# Altium schematic sheet Top Level.SchDoc
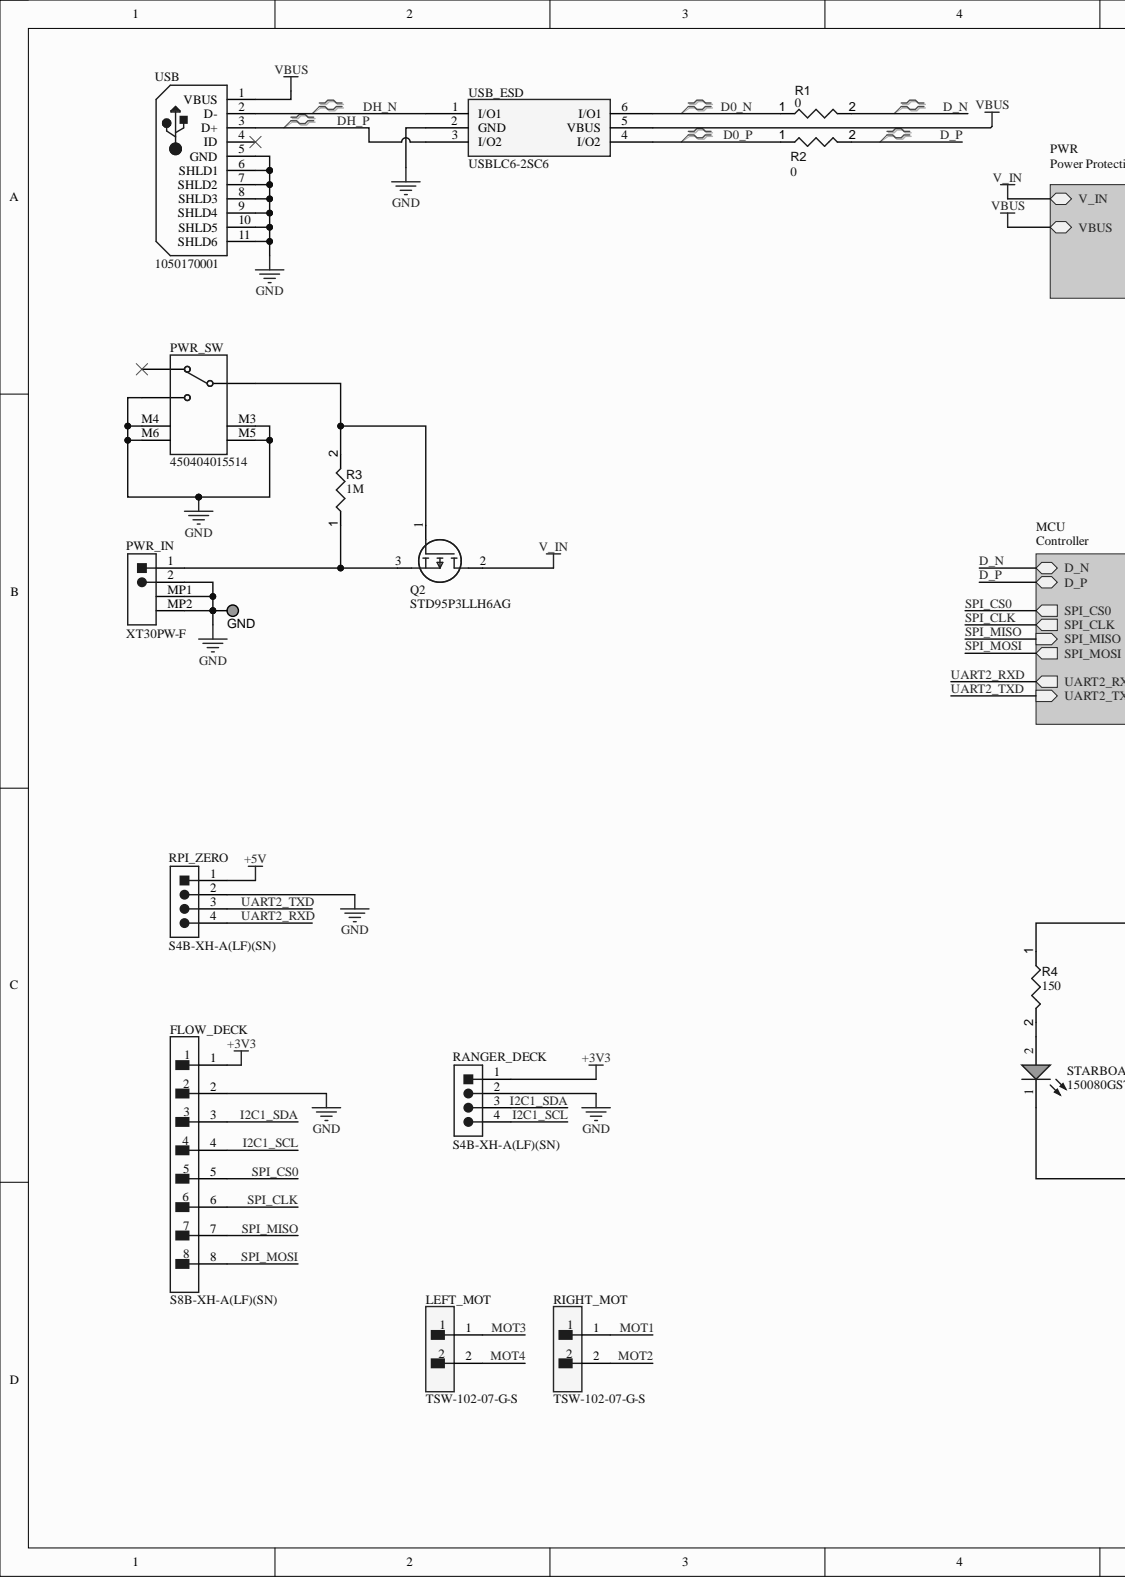
[diagram p0: Top Level.SchDoc, left]
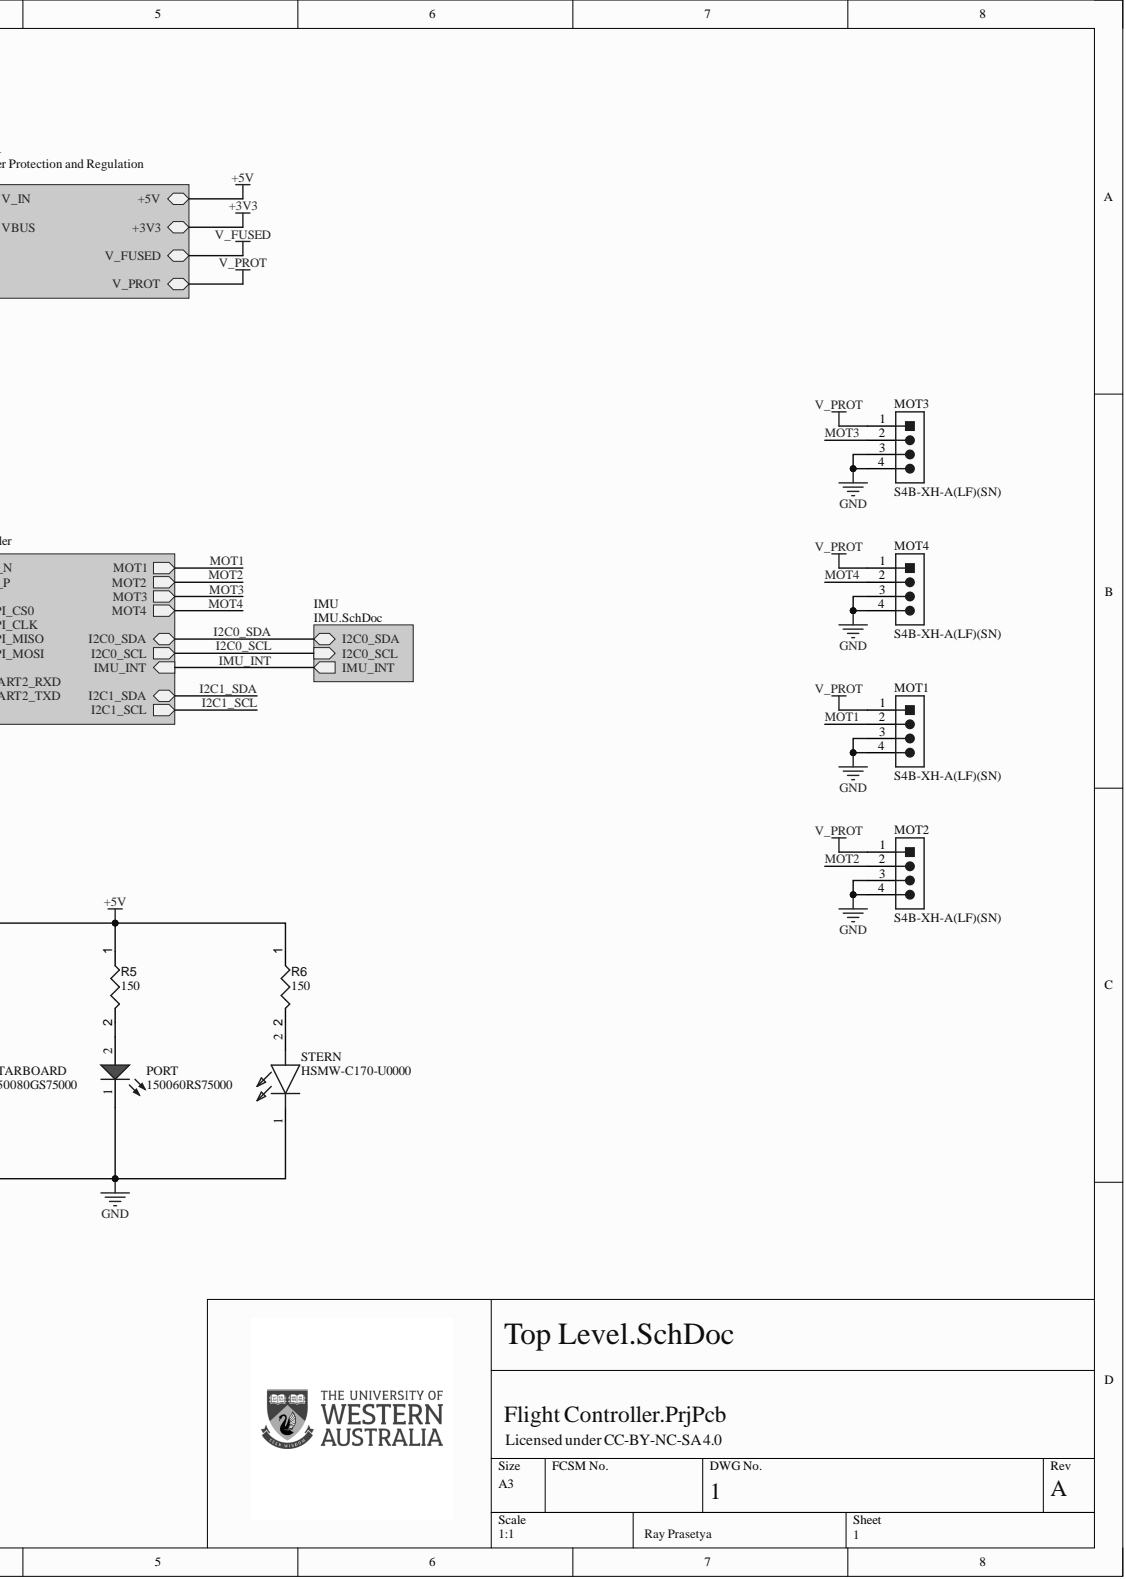
[diagram p1: Top Level.SchDoc, right]

## Parts
FLOW_DECK  S8B-XH-A(LF)(SN)  [FP-S8B-XH-A_LF_SN-MFG]
GND  5003  [KSTN-5003]  (value not drawn)
LEFT_MOT  TSW-102-07-G-S  [FP-TSW-102-07-G-S-MFG]
MOT1  S4B-XH-A(LF)(SN)  [JST-S4B-XH-A(LF)(SN)_V]
MOT2  S4B-XH-A(LF)(SN)  [JST-S4B-XH-A(LF)(SN)_V]
MOT3  S4B-XH-A(LF)(SN)  [JST-S4B-XH-A(LF)(SN)_V]
MOT4  S4B-XH-A(LF)(SN)  [JST-S4B-XH-A(LF)(SN)_V]
PORT  150060RS75000  [WL-SMCW_0603_150060xx75000]
PWR_IN  XT30PW-F  [XT30PWF]
PWR_SW  450404015514  [450404015514]
Q2  STD95P3LLH6AG  [DMP4047SK313]
R1  value 0  [FP-RC0603-MFG]  (hidden comment: RC0603FR-070RL)
R2  value 0  [FP-RC0603-MFG]  (hidden comment: RC0603FR-070RL)
R3  value 1M  [FP-AC0603-IPC_C]  (hidden comment: AC0603FR-071ML)
R4  value 150  [RESC1608X55X25NL10T15]  (hidden comment: RC0603FR-07150RL)
R5  value 150  [RESC1608X55X25NL10T15]  (hidden comment: RC0603FR-07150RL)
R6  value 150  [RESC1608X55X25NL10T15]  (hidden comment: RC0603FR-07150RL)
RANGER_DECK  S4B-XH-A(LF)(SN)  [JST-S4B-XH-A(LF)(SN)_V]
RIGHT_MOT  TSW-102-07-G-S  [FP-TSW-102-07-G-S-MFG]
RPI_ZERO  S4B-XH-A(LF)(SN)  [JST-S4B-XH-A(LF)(SN)_V]
STARBOARD  150080GS75000  [WL-SMCW_0805_150080xx75000]
STERN  HSMW-C170-U0000  [LEDC2012X90N]
USB  1050170001  Contact Style: USB  [USB-MICRO-B_V]
USB_ESD  USBLC6-2SC6  [STM-SOT23-6L_V]

## Nets
+3V3: FLOW_DECK.1 RANGER_DECK.1
+5V: R4.1 R5.1 R6.1 RPI_ZERO.1
GND: FLOW_DECK.2 GND.1 MOT1.3 MOT1.4 MOT2.3 MOT2.4 MOT3.3 MOT3.4 MOT4.3 MOT4.4 PORT.1 PWR_IN.2 PWR_IN.MP1 PWR_IN.MP2 PWR_SW.L2 PWR_SW.M3 PWR_SW.M4 PWR_SW.M5 PWR_SW.M6 RANGER_DECK.2 RPI_ZERO.2 STARBOARD.1 STERN.1 USB.5(GND) USB.6(SHLD1) USB.7(SHLD2) USB.8(SHLD3) USB.9(SHLD4) USB.10(SHLD5) USB.11(SHLD6) USB_ESD.2(GND)
V_IN: Q2.2
V_PROT: MOT1.1 MOT2.1 MOT3.1 MOT4.1
VBUS: USB.1(VBUS) USB_ESD.5(VBUS)
D0_N: R1.1 USB_ESD.6(I/O1)
D0_P: R2.1 USB_ESD.4(I/O2)
D_N: R1.2
D_P: R2.2
DH_N: USB.2(D-) USB_ESD.1(I/O1)
DH_P: USB.3(D+) USB_ESD.3(I/O2)
I2C1_SCL: FLOW_DECK.4 RANGER_DECK.4
I2C1_SDA: FLOW_DECK.3 RANGER_DECK.3
MOT1: MOT1.2 RIGHT_MOT.1
MOT2: MOT2.2 RIGHT_MOT.2
MOT3: LEFT_MOT.1 MOT3.2
MOT4: LEFT_MOT.2 MOT4.2
SPI_CLK: FLOW_DECK.6
SPI_CS0: FLOW_DECK.5
SPI_MISO: FLOW_DECK.7
SPI_MOSI: FLOW_DECK.8
UART2_RXD: RPI_ZERO.4
UART2_TXD: RPI_ZERO.3
NetPORT_2: PORT.2 R5.2
NetPWR_IN_1: PWR_IN.1 Q2.3 R3.1
NetPWR_SW_COM: PWR_SW.COM Q2.1 R3.2
NetPWR_SW_L1: PWR_SW.L1
NetR4_2: R4.2 STARBOARD.2
NetR6_2: R6.2 STERN.2
NetUSB_4: USB.4(ID)
I2C0_SCL:
I2C0_SDA:
IMU_INT:
V_FUSED:

## Library data of the parts
FLOW_DECK = CMP-002-00074-1: Conn Shrouded Header (4 Sides) HDR 8 POS 2.5mm Solder RA Thru-Hole Box; Component Kind: Standard; Library Name: Ray Prasetya
GND = CMP-002-00037-2: Test Point, Orange, Height 4.6 mm, Tail Length 3 mm, 1-Pin THD, RoHS; Manufacturer: Keystone; Case/Package: 5003; Colour: Orange; Component Kind: Standard; Connector Type: TestPoint; Contact Material: Bronze; Contact Plating: Tin; Datasheet URL: http://keyelco.com/userAssets/file/M65p56.pdf; Datasheet Version: Jun-15; Diameter: 2.54mm; Manufacturer URL: http://www.keyelco.com/; Mounting Technology: TH; Package Description: 1-Pin Through Hole Device, Dia 2.54 mm; Package Quantity: 10; Package Reference: 5003; Packaging: Bulk; Pins: 1; RoHS Compliant: True; Library Name: Ray Prasetya
LEFT_MOT RIGHT_MOT = CMP-02766-000084-1: CONN HEADER VERT 2POS 2.54MM; Manufacturer: Samtec; Conn Gender: Male; Conn Orientation: Straight; Current Rating: 6.3A; Number Of Contacts: 2; Voltage Rating: 550V; Automotive: No; Category: Conn; Component Kind: Standard; ComponentLink2Description: Datasheet; ComponentLink2URL: http://suddendocs.samtec.com/catalog_english/tsw_th.pdf; Contact Material: Gold,Nickel; Device Class L1: Connectors; Device Class L2: Headers and Wire Housings; Device Class L3: unset; Digikey Description: CONN HEADER VERT 2POS 2.54MM; Footprint Url: http://suddendocs.samtec.com/prints/tsw-xxx-xx-x-x-xx-xxx-footprint.pdf; Height: 8.71mm; Lead Free: Yes; Library Name: Altium Content Vault; Manufacturer Part Number: TSW-102-07-G-S; Mouser Description: Conn Unshrouded Header HDR 2 POS 2.54mm Solder ST Thru-Hole Automotive Bulk; Number Of Rows: 1; Octopart: https://octopart.com/tsw-102-07-g-s-samtec-272197; Package: TSW-102-07-G-S; Pitch: 2.54mm; RoHS: TRUE; Temperature: -55°C to +125°C; Temperature Range High: +125°C; Temperature Range Low: -55°C
MOT1-MOT4 RANGER_DECK RPI_ZERO = CMP-002-00034-2: Male Header, Pitch 2.5 mm, 1 x 4 Position, Height 6.1 mm, Tail Length 3.4 mm, -25 to 85 degC, RoHS, Bulk; Manufacturer: JST; Case/Package: S4B-XH-A(LF)(SN); Component Kind: Standard; Connector Type: Header; Datasheet URL: http://www.jst-mfg.com/product/pdf/eng/eXH.pdf; Datasheet Version: May-16; Gender: Male; Manufacturer URL: http://www.jst.com/home2.html; Max Operating Temperature: 85°C; Min Operating Temperature: -25°C; Mounting Technology: TH; Package Description: XH Series Disconnectable Crimp Style Connector, Pitch 2.5 mm, 1 x 4 Position, Height 6.1 mm, Tail Length 3.4 mm; Package Reference: S4B-XH-A(LF)(SN); RoHS Compliant: Unknown; Library Name: Ray Prasetya
PORT = CMP-007-00044-1: Single Color LED, Red, Water Clear, 1.1mm; Channels: 1; Forward Current: 30mA; Luminous Intensity-Nom: 250mcd; Overall Height: 0.7mm; Shape: Rectangular; Viewing Angle: 140°; Color: Red; Component Kind: Standard; Lens Style: WATER CLEAR; Max Operating Temperature: 85°C; Min Operating Temperature: -40°C; Mounting Technology: Surface Mount; Optoelectronic Device Type: SINGLE COLOR LED; Packaging: Tape and Reel; Pins: 2; Reach SVHC Compliant: Yes; RoHS Compliant: Yes; Size: 1.1mm; Terminal Pitch: 1.6mm; Library Name: Ray Prasetya
PWR_IN = CMP-002-00080-2: Socket; DC supply; XT30; female; PIN:2; on PCBs; THT; Colour: yellow; Manufacturer_Name: Amass; Component Kind: Standard; Datasheet Link: https://www.tme.eu/Document/ce4077e36b79046da520ca73227e15de/XT30PW%20SPEC.pdf; Design Item ID: XT30PW-F; Height: 5mm; Manufacturer_Part_Number: XT30PW-F; Source: SamacSys.SchLib; Library Name: Ray Prasetya
PWR_SW = CMP-011-00014-1: Slide Switch; Component Kind: Standard; RoHS Compliant: Yes; Library Name: Ray Prasetya
Q2 = CMP-008-000004-1: Trans MOSFET P-CH 30V 80A Automotive AEC-Q101 3-Pin(2+Tab) DPAK T/R; Manufacturer_Name: Diodes Incorporated; Manufacturer_Part_Number: DMP4047SK3-13; Drain to Source Resistance: 9.7mΩ; Drain to Source Voltage (Vdss): -30V; Max Power Dissipation: 104W; Number of Elements: 1; Rds On Max: 6.9mΩ; Arrow Part Number: DMP4047SK3-13; Arrow Price/Stock: https://www.arrow.com/en/products/dmp4047sk3-13/diodes-incorporated?region=nac; China RoHS: Non-Compliant; Component Kind: Standard; Continuous Drain Current (ID): 80A; Datasheet Link: https://www.diodes.com//assets/Datasheets/DMP4047SK3.pdf; Design Item ID: DMP4047SK3-13; Height: 2.52mm; Lifecycle Status: Production; Max Operating Temperature: 150°C; Min Breakdown Voltage: 30V; Min Operating Temperature: -55°C; Mouser Part Number: 621-DMP4047SK3-13; Mouser Price/Stock: https://www.mouser.co.uk/ProductDetail/Diodes-Incorporated/DMP4047SK3-13?qs=IL6XaBr%252B7X8AX9YdsiC3qA%3D%3D; Number of Terminals: 2; Packaging: Tape and Reel; REACH SVHC: No; RoHS: Compliant; Source: SamacSys.SchLib; Library Name: Ray Prasetya
R1 R2 = CMP-009-00189-1: Fixed Resistor, Metal Glaze/thick Film, 0.1W, 0ohm, Surface Mount, 0603; Power: 100mW; Rated Temperature: 70°C; Case/Package: 0603; Component Kind: Standard; JESD-609 Code: e3; Max Operating Temperature: 155°C; Min Operating Temperature: -55°C; Mounting Technology: Surface Mount; Package Shape: Rectangular; Packaging: Tape and Reel; Pins: 2; Reach SVHC Compliant: Yes; Resistor Type: FIXED RESISTOR; RoHS Compliant: Yes; Technology: METAL GLAZE/THICK FILM; Terminal Finish: Nickel; Termination: Wraparound; Library Name: Ray Prasetya
R3 = CMP-009-00177-1: Fixed Resistor, Metal Glaze/thick Film, 0.1W, 1000000ohm, 75V, 1% +/-Tol, 100ppm/Cel, Surface Mount, 0603; Additional Feature: LASER TRIMMABLE; Package Height: 0.45mm; Package Length: 1.6mm; Package Width: 0.8mm; Power: 100mW; Rated Temperature: 70°C; Reference Standard: AEC-Q200; Temperature Coefficient (ppm/Cel): 100; Case/Package: 0603; Component Kind: Standard; Construction: Chip; JESD-609 Code: e3; Max Operating Temperature: 155°C; Min Operating Temperature: -55°C; Mounting Technology: Surface Mount; Package Shape: Rectangular; Packaging: Tape and Reel; Pins: 2; Reach SVHC Compliant: Yes; Resistor Type: FIXED RESISTOR; RoHS Compliant: Yes; Technology: METAL GLAZE/THICK FILM; Terminal Finish: Nickel; Termination: Wraparound; Tolerance: 1%; Working Voltage: 75V; Library Name: Ray Prasetya
R4-R6 = CMP-009-00198-1: Fixed Resistor, Metal Glaze/thick Film, 0.1W, 150ohm, 75V, 1% +/-Tol, 100ppm/Cel, Surface Mount, 0603; Additional Feature: LASER TRIMMABLE; Package Height: 0.45mm; Package Length: 1.6mm; Package Width: 0.8mm; Power: 100mW; Rated Temperature: 70°C; Temperature Coefficient (ppm/Cel): 100; Case/Package: 0603; Component Kind: Standard; Construction: Chip; JESD-609 Code: e3; Max Operating Temperature: 155°C; Min Operating Temperature: -55°C; Mounting Technology: Surface Mount; Package Shape: Rectangular; Packaging: Tape and Reel; Pins: 2; Reach SVHC Compliant: Yes; Resistor Type: FIXED RESISTOR; RoHS Compliant: Yes; Technology: METAL GLAZE/THICK FILM; Terminal Finish: Nickel; Termination: Wraparound; Tolerance: 1%; Working Voltage: 75V; Library Name: Ray Prasetya
STARBOARD = CMP-007-00045-1: Single Color LED, Green, Water Clear, 1.4mm; Channels: 1; Forward Current: 30mA; Luminous Intensity-Nom: 450mcd; Overall Height: 0.7mm; Shape: Rectangular; Viewing Angle: 140°; Color: Green; Component Kind: Standard; Lens Style: WATER CLEAR; Max Operating Temperature: 85°C; Min Operating Temperature: -40°C; Mounting Technology: Surface Mount; Optoelectronic Device Type: SINGLE COLOR LED; Packaging: Tape and Reel; Pins: 2; Reach SVHC Compliant: Yes; RoHS Compliant: Yes; Size: 1.4mm; Terminal Pitch: 2.1mm; Library Name: Ray Prasetya
STERN = CMP-007-00047-1: BROADCOM LIMITED - HSMW-C170-U0000 - LED; Manufacturer_Name: Avago Technologies; Component Kind: Standard; Datasheet Link: https://componentsearchengine.com/Datasheets/2/HSMW-C170-U0000.pdf; Design Item ID: HSMW-C170-U0000; Height: 0.9mm; Manufacturer_Part_Number: HSMW-C170-U0000; Mouser Part Number: 630-HSMW-C170-U0000; Mouser Price/Stock: https://www.mouser.co.uk/ProductDetail/Broadcom-Avago/HSMW-C170-U0000?qs=tMOYG%252Bw4%252BLwGyeHODzQdug%3D%3D; Source: SamacSys.SchLib; Library Name: Ray Prasetya
USB = CMP-002-00073-1: Telecom and Datacom Connector, 5 Contact(s), Female, Right Angle, Surface Mount Terminal, Detent, Receptacle; Additional Feature: Shielded; Board Mounting Option: PEG; Body Breadth: 0.114inch; Body Depth: 0.213inch; Body Length: 0.315inch; Contact Resistance: 30mR; Dielectric Withstanding Voltage (AC): 100V; DIN Conformance: No; Durability: 10000Cycles; Insulation Resistance: 1GR; Mounting Option 2: LOCKING; Number Of Connectors: 1; Number of Contacts: 5; Option: General Purpose; PCB Contact Pattern: RECTANGULAR; Polarization Key: POLARIZED HOUSING; Rated Current (Signal): 1.8A; Reference Standard: UL; Reliability: COMMERCIAL; Body/Shell Style: RECEPTACLE; Component Kind: Standard; Connector Type: TELECOM AND DATACOM CONNECTOR; Contact Finish - Mating: GOLD; Contact Finish - Termination: Gold (Au) - with Nickel (Ni) barrier; Contact Gender: Female; Contact Material: Copper Alloy; Empty Shell: No; Filter Feature: No; IEC Conformance: No; Insulation Material: Polymer; JESD-609 Code: e4; Max Operating Temperature: 85°C; MIL Conformance: No; Min Operating Temperature: -30°C; Mixed Contacts: No; Mounting Option 1: DETENT; Mounting Style: RIGHT ANGLE; Mounting Technology: Cable; Number Of PCB Rows: 1; Reach SVHC Compliant: Yes; RoHS Compliant: Yes; Shell Finish: Tin; Shell Material: Stainless Steel; Terminal Pitch: 0.635mm; Termination: SMD/SMT; Library Name: Ray Prasetya
USB_ESD = CMP-015-00009-1: Trans Voltage Suppressor Diode, 5V V(RWM), Unidirectional, 1 Element, Silicon; Additional Feature: HIGH RELIABILITY; Clamping Voltage-Max: 17V; Min Breakdown Voltage: 6V; Number of Elements: 1; Package Body Material: Plastic; Qualification Status: Not Qualified; Reference Standard: IEC-61000-4-2; Component Kind: Standard; Diode Element Material: SILICON; Diode Type: TRANS VOLTAGE SUPPRESSOR; JESD-30 Code: R-PDSO-G6; JESD-609 Code: e3; Max Operating Temperature: 125°C; Min Operating Temperature: -40°C; Moisture Sensitivity Level: 1; Package Shape: Rectangular; Package Style: SMALL OUTLINEMeter; Peak Reflow Temperature (Cel): 260°C; Pins: 6; Polarity: Unidirectional; Reach SVHC Compliant: Yes; Rep Pk Reverse Voltage-Max: 5V; RoHS Compliant: Yes; Surface Mount: Yes; Technology: AVALANCHE; Terminal Finish: Tin; Terminal Form: Gull Wing; Terminal Position: DUAL; Library Name: Ray Prasetya
